# Altium schematic sheet full.SchDoc
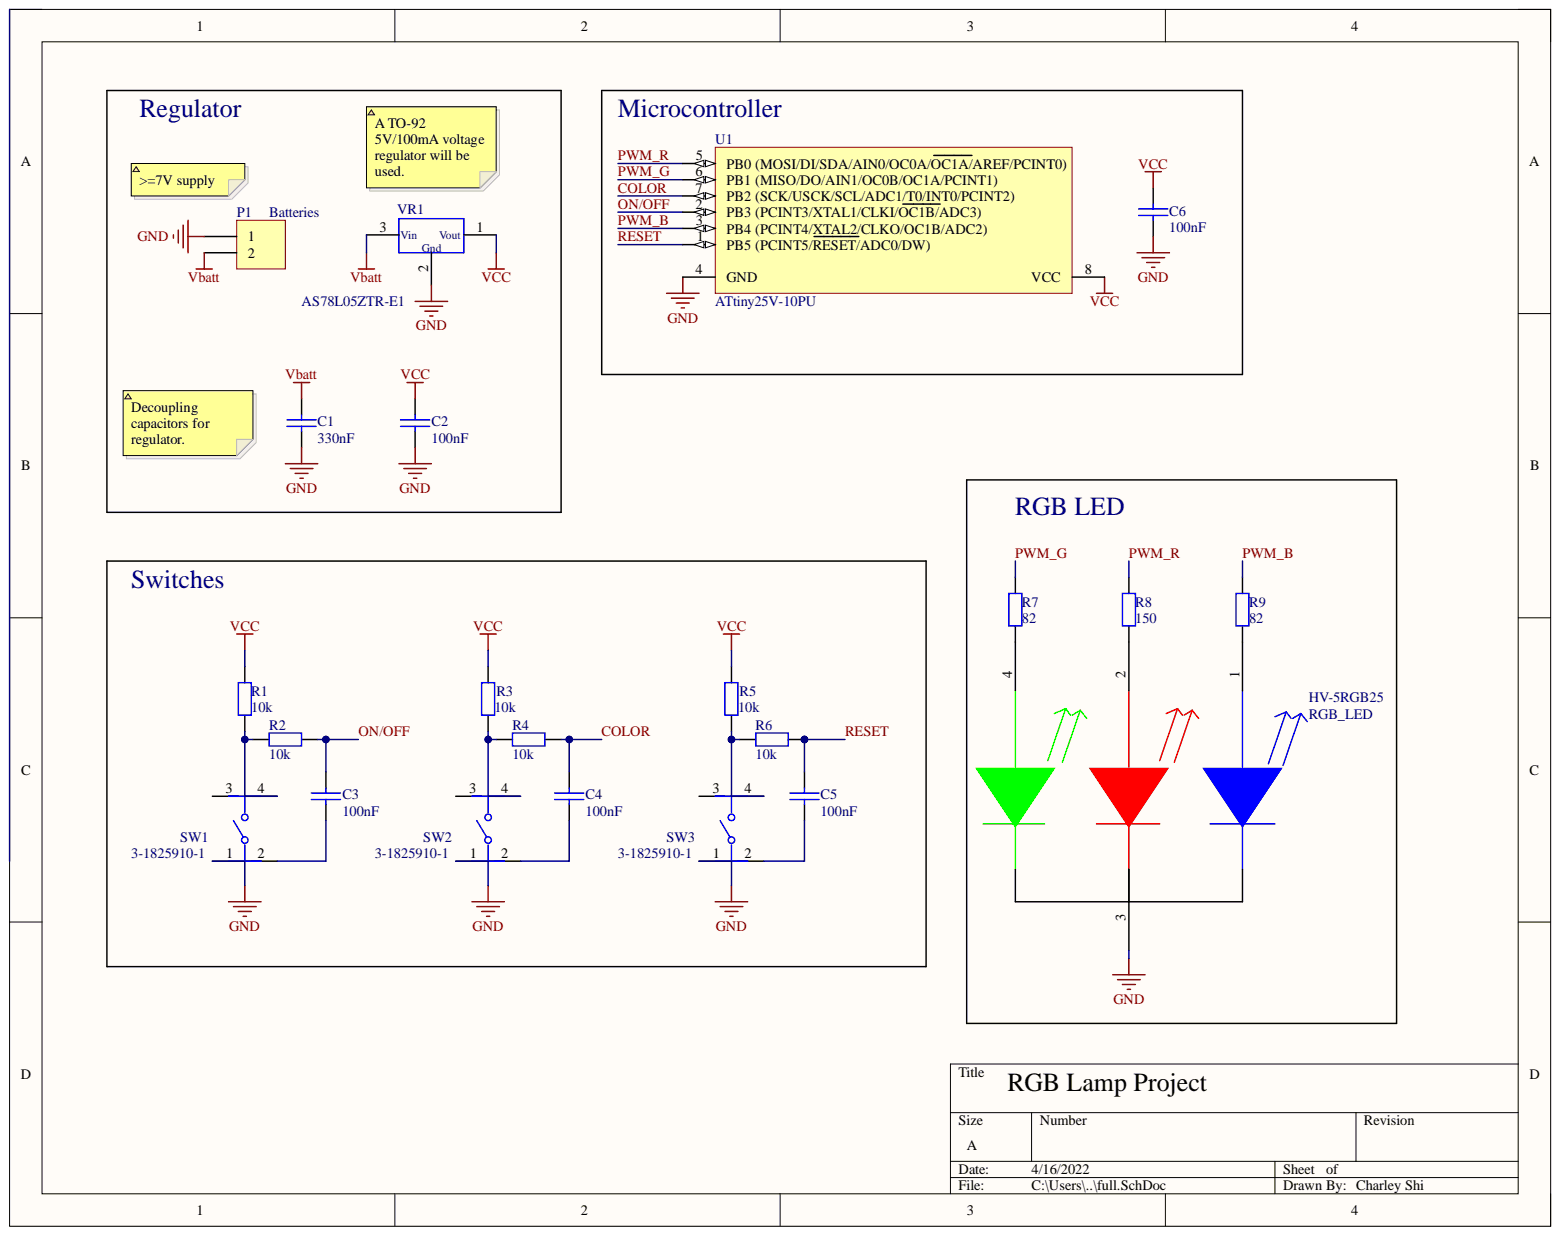
[diagram p0: full.SchDoc]

## Parts
C1  330nF  [ece-RAD0.2]
C2  100nF  [ece-RAD0.2]
C3  100nF  [ece-RAD0.2]
C4  100nF  [ece-RAD0.2]
C5  100nF  [ece-RAD0.2]
C6  100nF  [ece-RAD0.2]
HV-5RGB25  RGB_LED  [HV-5RGB25]
P1  Batteries  [ece-HDR1X2]
R1  10k  [ece-AXIAL0.4]
R2  10k  [ece-AXIAL0.4]
R3  10k  [ece-AXIAL0.4]
R4  10k  [ece-AXIAL0.4]
R5  10k  [ece-AXIAL0.4]
R6  10k  [ece-AXIAL0.4]
R7  82  [ece-AXIAL0.4]
R8  150  [ece-AXIAL0.4]
R9  82  [ece-AXIAL0.4]
SW1  3-1825910-1  [FP-3-1825910-1-MFG]
SW2  3-1825910-1  [FP-3-1825910-1-MFG]
SW3  3-1825910-1  [FP-3-1825910-1-MFG]
U1  ATtiny25V-10PU  [8P3]
VR1  AS78L05ZTR-E1  [ece-TO-92]

## Nets
GND: C1.2 C2.2 C6.2 HV-5RGB25.3 P1.1 SW1.1 SW2.1 SW3.1 U1.4(GND) VR1.2
Vbatt: C1.1 P1.2 VR1.3
VCC: C2.1 C6.1 R1.2 R3.2 R5.2 U1.8(VCC) VR1.1
COLOR: C4.1 R4.2 U1.7(PB2_(SCK/USCK/SCL/ADC1/T0/INT0/PCINT2))
ON/OFF: C3.1 R2.2 U1.2(PB3_(PCINT3/XTAL1/CLKI/OC1B/ADC3))
PWM_B: R9.2 U1.3(PB4_(PCINT4/XTAL2/CLKO/OC1B/ADC2))
PWM_G: R7.2 U1.6(PB1_(MISO/DO/AIN1/OC0B/OC1A/PCINT1))
PWM_R: R8.2 U1.5(PB0_(MOSI/DI/SDA/AIN0/OC0A/OC1A/AREF/PCINT0))
RESET: C5.1 R6.2 U1.1(PB5_(PCINT5/RESET/ADC0/DW))
NetC3_2: C3.2 SW1.2
NetC4_2: C4.2 SW2.2
NetC5_2: C5.2 SW3.2
NetHV-5RGB25_1: HV-5RGB25.1 R9.1
NetHV-5RGB25_2: HV-5RGB25.2 R8.1
NetHV-5RGB25_4: HV-5RGB25.4 R7.1
NetR1_1: R1.1 R2.1 SW1.4
NetR3_1: R3.1 R4.1 SW2.4
NetR5_1: R5.1 R6.1 SW3.4

Not connected: SW1 3; SW2 3; SW3 3

## Library data of the parts
C1-C6 = CAP: Capacitor Non Polarised Through Hole; Component Kind: Standard; Library Name: ece components.SchLib
P1 = Header 2: Header, 2-Pin; Component Kind: Standard; LatestRevisionDate: 17-Jul-2002; LatestRevisionNote: Re-released for DXP Platform.; Library Name: ece components.SchLib; Signal Integrity: Connector; Publisher: Altium Limited
R1-R9 = Res2: Resistor; Component Kind: Standard; LatestRevisionDate: 17-Jul-2002; LatestRevisionNote: Re-released for DXP Platform.; Library Name: ece components.SchLib; PackageReference: AXIAL-0.4; Published: 8-Jun-2000; Signal Integrity: Res; Simulation: RESISTOR; Publisher: Altium Limited
SW1-SW3 = CMP-03407-000001-1: SWITCH TACTILE SPST-NO 0.05A 24V; Manufacturer: TE Connectivity; Contact Current Rating: 50mA; Contact Resistance: 100mΩ; Electromechanical Life: 100000Cycles; Operating Force: 160gf; Automotive: No; Category: Switch; Component Kind: Standard; ComponentLink2Description: Datasheet; ComponentLink2URL: https://www.te.com/commerce/DocumentDelivery/DDEController?Action=srchrtrv&DocNm=1825910&DocType=Customer+Drawing&DocLang=English; Device Class L1: Electromechanical; Device Class L2: Switches; Device Class L3: Tactile Switches; Digikey Description: SWITCH TACTILE SPST-NO 0.05A 24V; Height: 17.3mm; Lead Free: Yes; Library Name: Altium Content Vault; Manufacturer Part Number: 3-1825910-1; Mount: Through Hole; Octopart: https://octopart.com/3-1825910-1-te+connectivity-46506874; Package: 0; RoHS: TRUE; Temperature: -35°C to +85°C; Temperature Range High: +85°C; Temperature Range Low: -35°C; Throw Configuration: SPST-NO
U1 = CMP-0093-00022-2: 8-bit AVR Microcontroller, 10MHz, 1.8-5.5V, 2KB Flash, 128 Bytes EEPROM, 128 Bytes SRAM, 8-pin PDIP, Industrial Grade (-40°C to 85°C), Pb-Free; Code_JEDEC: MS-001-BA; Component Kind: Standard; ComponentLink1Description: Manufacturer URL; ComponentLink1URL: http://www.atmel.com/; ComponentLink2Description: ATtiny25/45/85 Datasheet; ComponentLink2URL: http://www.atmel.com/dyn/resources/prod_documents/doc2586.pdf; ComponentLink3Description: ATtiny25/45/85 Summary; ComponentLink3URL: http://www.atmel.com/dyn/resources/prod_documents/2586S.pdf; DatasheetVersion: revN, Apr-2011; Library Name: Altium Content Vault; PackageDescription: 8-Lead, 0.300" Wide Body, Plastic Dual In-Line Package (PDIP); PackageReference: 8P3; PackageVersion: revB, Jan-2002; RevisionNote: DatasheetVersion updated. URLs added.
VR1 = VoltRegSmall: Voltage Regulator; Component Kind: Standard; Library Name: ece components.SchLib
HV-5RGB25 = RGB_LED: Component Kind: Standard; Library Name: RGB_LED.SchLib
